# Altium schematic sheet Copy of LCM.SchDoc
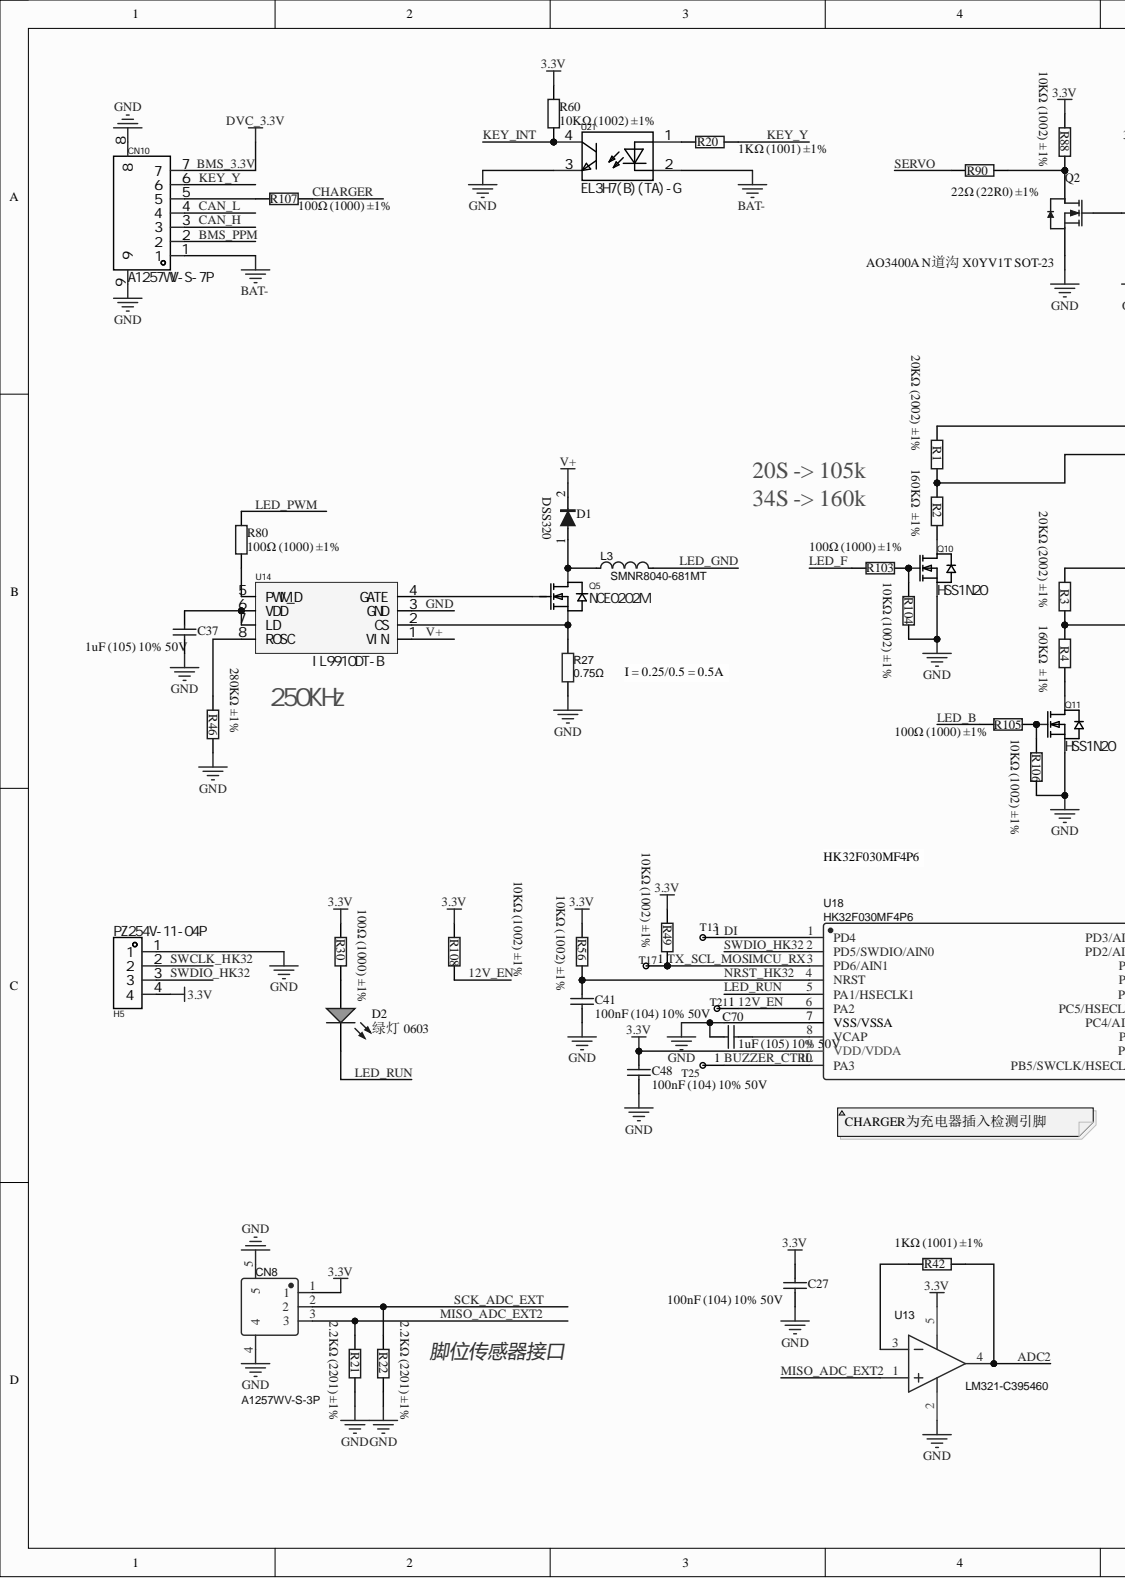
[diagram p0: Copy of LCM.SchDoc, left]
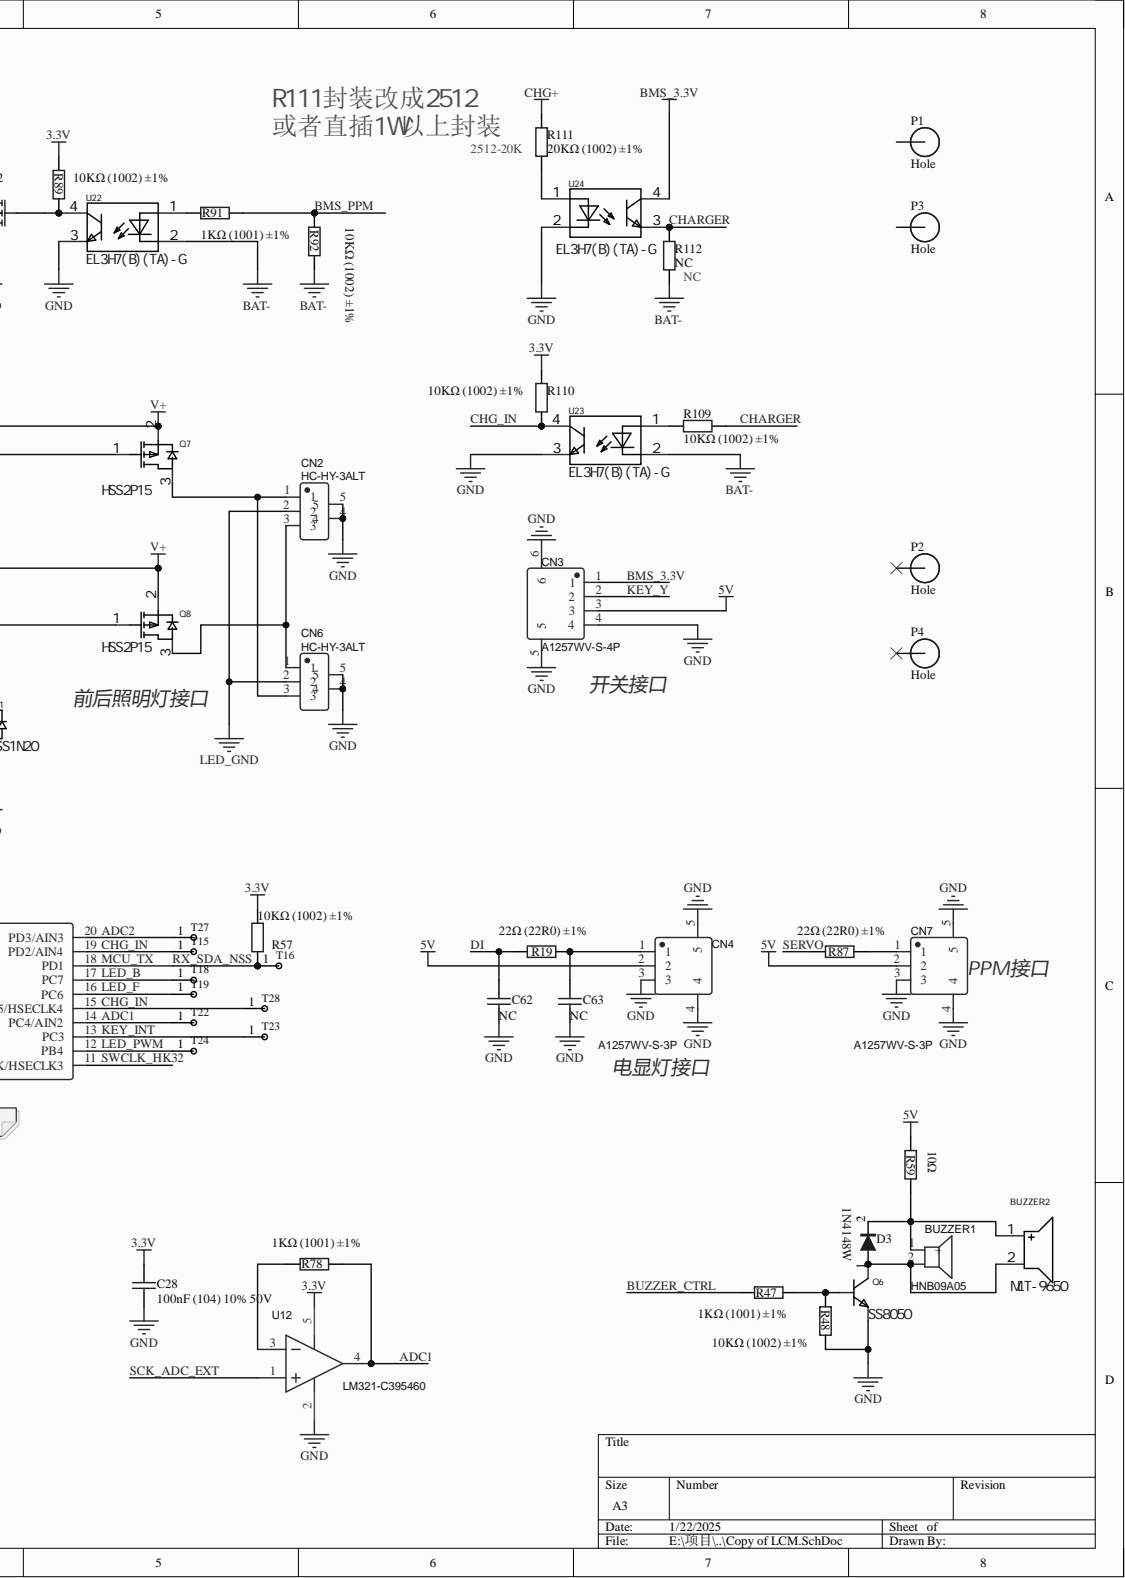
[diagram p1: Copy of LCM.SchDoc, right]

## Parts
BUZZER1  HNB09A05  [BUZ-TH_BD9.6-P5.00-D0.7-FD]
BUZZER2  MLT-9650  [BUZ-SMD_L9.6-W9.6-LS10.0-R]
C27  100nF (104) 10% 50V  [0603_C]
C28  100nF (104) 10% 50V  [0603_C]
C37  1uF (105) 10% 50V  [0603_C]
C41  100nF (104) 10% 50V  [0603_C]
C48  100nF (104) 10% 50V  [0603_C]
C62  NC  [0603_C]
C63  NC  [0603_C]
C70  1uF (105) 10% 50V  [0603_C]
CN2  HC-HY-3ALT  [CONN-SMD_HC-HY-3ALT]
CN3  A1257WV-S-4P  [CONN-SMD_A1257WV-S-4P]
CN4  A1257WV-S-3P  [CONN-SMD_A1257WV-S-3P]
CN6  HC-HY-3ALT  [CONN-SMD_HC-HY-3ALT]
CN7  A1257WV-S-3P  [CONN-SMD_A1257WV-S-3P]
CN8  A1257WV-S-3P  [CONN-SMD_A1257WV-S-3P]
CN10  A1257WV-S-7P  [CONN-SMD_A1257WV-S-7P]
D1  DSS320  [SOD-123]
D2  绿灯 0603  Package: 0603  [0603_LED_S1]
D3  1N4148W  [SOD-123]
H5  PZ254V-11-04P  [HDR-TH_4P-P2.54-V-M]
L3  SMNR8040-681MT  [IND-SMD_L8.0-W8.0]
P1  Hole  [Power Pad - Hole]
P2  Hole  [Power Pad - Hole]
P3  Hole  [Power Pad - Hole]
P4  Hole  [Power Pad - Hole]
Q2  AO3400A N道沟 X0YV1T SOT-23  Package: SOT-23  [SOT-23-3L]
Q5  NCE0202M  [SOT-89-3_L4.5-W2.5-P1.50-LS4.2-BR]
Q6  SS8050  [SOT-23-3_L2.9-W1.3-P1.90-LS2.4-BR]
Q7  HSS2P15  [SOT-23-3_L2.9-W1.6-P1.90-LS2.8-BR]
Q8  HSS2P15  [SOT-23-3_L2.9-W1.6-P1.90-LS2.8-BR]
Q10  HSS1N20  [SOT-23-3_L2.9-W1.6-P1.90-LS2.8-BR]
Q11  HSS1N20  [SOT-23-3_L2.9-W1.6-P1.90-LS2.8-BR]
R1  20KΩ (2002) ±1%  [0603_R]
R2  160KΩ  ±1%  [0603_R]
R3  20KΩ (2002) ±1%  [0603_R]
R4  160KΩ  ±1%  [0603_R]
R19  22Ω (22R0) ±1%  [0603_R]
R20  1KΩ (1001) ±1%  [0603_R]
R21  2.2KΩ (2201) ±1%  [0603_R]
R22  2.2KΩ (2201) ±1%  [0603_R]
R27  0.75Ω  [1206_R]
R30  100Ω (1000) ±1%  [0603_R]
R42  1KΩ (1001) ±1%  [0603_R]
R46  280KΩ ±1%  [0603_R]
R47  1KΩ (1001) ±1%  [0603_R]
R48  10KΩ (1002) ±1%  [0603_R]
R49  10KΩ (1002) ±1%  [0603_R]
R56  10KΩ (1002) ±1%  [0603_R]
R57  10KΩ (1002) ±1%  [0603_R]
R59  10Ω  [0805_R]
R60  10KΩ (1002) ±1%  [0603_R]
R78  1KΩ (1001) ±1%  [0603_R]
R80  100Ω (1000) ±1%  [0603_R]
R87  22Ω (22R0) ±1%  [0603_R]
R88  10KΩ (1002) ±1%  [0603_R]
R89  10KΩ (1002) ±1%  [0603_R]
R90  22Ω (22R0) ±1%  [0603_R]
R91  1KΩ (1001) ±1%  [0603_R]
R92  10KΩ (1002) ±1%  [0603_R]
R103  100Ω (1000) ±1%  [0603_R]
R104  10KΩ (1002) ±1%  [0603_R]
R105  100Ω (1000) ±1%  [0603_R]
R106  10KΩ (1002) ±1%  [0603_R]
R107  100Ω (1000) ±1%  [0603_R]
R108  10KΩ (1002) ±1%  [0603_R]
R109  10KΩ (1002) ±1%  [0603_R]
R110  10KΩ (1002) ±1%  [0603_R]
R111  20KΩ (1002) ±1%  [2512_R]
R112  NC  [0603_R]
T13  Test Point  [TESTPOINT_1MM - small]  (value not drawn)
T15  Test Point  [TESTPOINT_1MM - small]  (value not drawn)
T16  Test Point  [TESTPOINT_1MM - small]  (value not drawn)
T17  Test Point  [TESTPOINT_1MM - small]  (value not drawn)
T18  Test Point  [TESTPOINT_1MM - small]  (value not drawn)
T19  Test Point  [TESTPOINT_1MM - small]  (value not drawn)
T21  Test Point  [TESTPOINT_1MM - small]  (value not drawn)
T22  Test Point  [TESTPOINT_1MM - small]  (value not drawn)
T23  Test Point  [TESTPOINT_1MM - small]  (value not drawn)
T24  Test Point  [TESTPOINT_1MM - small]  (value not drawn)
T25  Test Point  [TESTPOINT_1MM - small]  (value not drawn)
T27  Test Point  [TESTPOINT_1MM - small]  (value not drawn)
T28  Test Point  [TESTPOINT_1MM - small]  (value not drawn)
U12  LM321-C395460  [SOT-23-5_L3.0-W1.7-P0.95-LS2.8-BL]
U13  LM321-C395460  [SOT-23-5_L3.0-W1.7-P0.95-LS2.8-BL]
U14  IL9910DT-B  [SO-8_L4.9-W3.9-P1.27-LS5.9-BL]
U18  HK32F030MF4P6  [TSSOP-20_L6.5-W4.4-P0.65-LS6.4-BL]
U21  EL3H7(B)(TA)-G  [SOP-4_L4.4-W2.8-P1.27-LS7.0-TL]
U22  EL3H7(B)(TA)-G  [SOP-4_L4.4-W2.8-P1.27-LS7.0-TL]
U23  EL3H7(B)(TA)-G  [SOP-4_L4.4-W2.8-P1.27-LS7.0-TL]
U24  EL3H7(B)(TA)-G  [SOP-4_L4.4-W2.8-P1.27-LS7.0-TL]

## Nets
3.3V: C27.1 C28.1 C48.1 CN8.1 H5.4 R30.2 R49.1 R56.1 R57.1 R60.1 R88.1 R89.1 R108.1 R110.1 U12.5 U13.5 U18.9(VDD/VDDA)
5V: CN3.3 CN4.2 CN7.2 R59.2
BAT-: CN10.1 R92.2 R112.1 U21.2 U22.2 U23.2
CHG+: R111.2
12V_EN: R108.2 T21.1 U18.6(PA2)
ADC1: R78.1 T22.1 U12.4 U18.14(PC4/AIN2)
ADC2: R42.1 T27.1 U13.4 U18.20(PD3/AIN3)
BMS_3.3V: CN3.1 CN10.7 U24.4
BMS_PPM: CN10.2 R91.1 R92.1
BUZZER_CTRL: R47.2 T25.1 U18.10(PA3)
CAN_H: CN10.3
CAN_L: CN10.4
CHARGER: R107.1 R109.2 R112.2 U24.3
CHG_IN: R110.2 T15.1 T28.1 U18.15(PC5/HSECLK4) U18.19(PD2/AIN4) U23.4
DI: C62.1 R19.1 T13.1 U18.1(PD4)
GND: C27.2 C28.2 C37.1 C41.2 C48.2 C62.2 C63.2 C70.1 CN2.4 CN2.5 CN3.4 CN3.5 CN3.6 CN4.3 CN4.4 CN4.5 CN6.4 CN6.5 CN7.3 CN7.4 CN7.5 CN8.4 CN8.5 CN10.8 CN10.9 H5.1 Q2.2 Q6.2 Q10.2 Q11.2 R21.1 R22.1 R27.2 R46.1 R48.2 R104.2 R106.2 U12.2 U13.2 U14.3(GND) U18.7(VSS/VSSA) U21.3 U22.3 U23.3 U24.2
KEY_INT: R60.2 T23.1 U18.13(PC3) U21.4
KEY_Y: CN3.2 CN10.6 R20.1
LED_B: R105.2 T18.1 U18.17(PC7)
LED_F: R103.2 T19.1 U18.16(PC6)
LED_GND: CN2.2 CN6.2 L3.2
LED_PWM: R80.2 T24.1 U18.12(PB4)
LED_RUN: D2.K U18.5(PA1/HSECLK1)
MCU_RX: R49.2 T17.1 U18.3(PD6/AIN1)
MCU_TX: R57.2 T16.1 U18.18(PD1)
MISO_ADC_EXT2: CN8.3 R21.2 U13.1
NRST_HK32: C41.1 R56.2 U18.4(NRST)
SCK_ADC_EXT: CN8.2 R22.2 U12.1
SERVO: R87.1 R90.1
SWCLK_HK32: H5.2 U18.11(PB5/SWCLK/HSECLK3)
SWDIO_HK32: H5.3 U18.2(PD5/SWDIO/AIN0)
V+: D1.2 Q7.2 Q8.2 R1.1 R3.1 U14.1(VIN)
NetBUZZER1_1: BUZZER1.1 BUZZER2.1 D3.2 R59.1
NetBUZZER1_2: BUZZER1.2 BUZZER2.2 D3.1 Q6.3
NetC37_2: C37.2 U14.6(VDD) U14.7(LD)
NetC63_1: C63.1 CN4.1 R19.2
NetC70_2: C70.2 U18.8(VCAP)
NetCN2_1: CN2.1 CN6.3 Q7.3
NetCN2_3: CN2.3 CN6.1 Q8.3
NetCN7_1: CN7.1 R87.2
NetCN10_5: CN10.5 R107.2
NetD1_1: D1.1 L3.1 Q5.2
NetD2_A: D2.A R30.1
NetQ2_1: Q2.1 R89.2 U22.4
NetQ2_3: Q2.3 R88.2 R90.2
NetQ5_1: Q5.1 U14.4(GATE)
NetQ5_3: Q5.3 R27.1 U14.2(CS)
NetQ6_1: Q6.1 R47.1 R48.1
NetQ7_1: Q7.1 R1.2 R2.1
NetQ8_1: Q8.1 R3.2 R4.1
NetQ10_1: Q10.1 R103.1 R104.1
NetQ10_3: Q10.3 R2.2
NetQ11_1: Q11.1 R105.1 R106.1
NetQ11_3: Q11.3 R4.2
NetR20_2: R20.2 U21.1
NetR42_2: R42.2 U13.3
NetR46_2: R46.2 U14.8(ROSC)
NetR78_2: R78.2 U12.3
NetR80_1: R80.1 U14.5(PWM_D)
NetR91_2: R91.2 U22.1
NetR109_1: R109.1 U23.1
NetR111_1: R111.1 U24.1

Not connected: P1 1; P2 1; P3 1; P4 1

## Library data of the parts
BUZZER1 = HNB09A05: BOM_Manufacturer: HNDZ; BOM_Manufacturer Part: HNB09A05; BOM_Supplier: LCSC; BOM_Supplier Part: C96493; Component Kind: Standard; spicePre: B; spiceSymbolName: HNB09A05
BUZZER2 = MLT-9650: Manufacturer: 华能; Add into BOM: yes; Operating Voltage: 3V~7V; Oscillator Circuit: 有源(内含驱动线路); Sound Pressure Level (SPL): 85dB@5V,10cm; Symbol: HND-9650B; Technology Type: 电磁式; Value: 2.7kHz; Component Kind: Standard; Convert to PCB: yes; Datasheet: https://atta.szlcsc.com/upload/public/pdf/source/20170914/C96127_1505374148680969227.pdf; Device: MLT-9650; Height: 5.5mm; JLCPCB Part Class: Extended Part; LCSC Part Name: 耐环保MLT-9650; Length: 9.6mm; Manufacturer Part: MLT-9650; Supplier: LCSC; Supplier Footprint: -; Supplier Part: C96127; Width: 9.6mm
C27 C28 C41 C48 C62 C63 = 0603 100nF (104) 10% 50V: 贴片电容; Component Kind: Standard; ComponentLink1Description: 供应商链接; ComponentLink1URL: http://www.szlcsc.com/product/details_15331.html; Library Name: Cap.SchLib; Package: 0603; Supplier: LC; SuppliersPartNumber: C14663
C37 C70 = 0603 1uF (105) 10% 50V: 贴片电容; Component Kind: Standard; ComponentLink1Description: 供应商链接; ComponentLink1URL: http://www.szlcsc.com/product/details_16531.html; Package: 0603; Supplier: LC; SuppliersPartNumber: C15849
  C37: Library Name: Cap.SchLib
  C70: Library Name: Miscellaneous Devices LC.IntLib
CN2 CN6 = HC-HY-3ALT: BOM_Manufacturer: HCTL(华灿天禄); Contributor: LCSC; BOM_Manufacturer Part: HC-HY-3ALT; BOM_Supplier: LCSC; BOM_Supplier Part: C2845717; Component Kind: Standard; spicePre: C; spiceSymbolName: HC-HY-3ALT
CN3 = A1257WV-S-4P: BOM_Manufacturer: Changjiang Connectors; Contributor: LCSC; BOM_JLCPCB Part Class: Extended Part; BOM_Manufacturer Part: A1257WV-S-4P; BOM_Supplier: LCSC; BOM_Supplier Part: C225120; Component Kind: Standard; spicePre: C; spiceSymbolName: A1257WV-S-4P
CN4 CN7 CN8 = A1257WV-S-3P: BOM_Manufacturer: Changjiang Connectors; Contributor: LCSC; BOM_JLCPCB Part Class: Extended Part; BOM_Manufacturer Part: A1257WV-S-3P; BOM_Supplier: LCSC; BOM_Supplier Part: C225119; Component Kind: Standard; Library Name: SCH.SchLib; spicePre: C; spiceSymbolName: A1257WV-S-3P
CN10 = A1257WV-S-7P: Manufacturer: CJT(长江连接器); Add into BOM: yes; Number of PINs Per Row: 7; Pins Structure: 1x7P; Pitch: 1.25mm; Reference Series: GH; Component Kind: Standard; Contact Material: 黄铜; Convert to PCB: yes; Datasheet: https://atta.szlcsc.com/upload/public/pdf/source/20181008/C272994_C9E89FA8A36D0009CAD30AD8C59C2782.pdf; Device: A1257WV-S-7P; JLCPCB Part Class: Extended Part; LCSC Part Name: 系列:GH 间距:1.25mm 1x7P; Manufacturer Part: A1257WV-S-7P; Mounting Style: -; Number of Pins: 7P; Number of Rows: 1; Row Spacing: -; Supplier: LCSC; Supplier Footprint: SMD,P=1.25mm; Supplier Part: C225123; Symbol: A1257WV-S-7P
D1 D3 = SOD-123 1N4148W: 二极管; Component Kind: Standard; Library Name: Diode.SchLib
D2 = 0603 绿灯: 发光二极管; Component Kind: Standard; ComponentLink1Description: 供应商链接; ComponentLink1URL: http://www.szlcsc.com/product/details_73152.html; Library Name: LED.SchLib; Supplier: LC; SuppliersPartNumber: C72043
H5 = PZ254V-11-04P: Manufacturer: XFCN(兴飞); Add into BOM: yes; Circluar Pins/Square Pins: 方针; Current Rating (Max): 3A; Pin Structure: 1x4P; Pitch: 2.54mm; Symbol: PZ254V-11-04P_C2691448; Voltage Rating (Max): 250V; Color: 黑色; Component Kind: Standard; Contact Material: -; Convert to PCB: yes; Datasheet: https://atta.szlcsc.com/upload/public/pdf/source/20200309/C492403_F9F19131791D08044AD4F84F8E751A34.pdf; Device: PZ254V-11-04P; Insulation Height: 2.5mm; JLCPCB Part Class: Extended Part; LCSC Part Name: 间距:2.54mm 1x4P 直插 方针; Length of End Connection Pin: 3mm; Length of Mating Pin: 6mm; Manufacturer Part: PZ254V-11-04P; Mounting Type: 直插; Number of Pins: 4P; Number of Rows: 单排; Operating Temperature Range: -40℃~+105℃; Row Spacing: -; Supplier: LCSC; Supplier Footprint: 插件,P=2.54mm; Supplier Part: C2691448
L3 = 220uH: BOM_Manufacturer: Sunlord; BOM_Manufacturer Part: SWPA8040S221MT; nameAlias: Inductance; BOM_JLCPCB Part Class: Extended Part; BOM_Supplier: LCSC; BOM_Supplier Part: C86663; Component Kind: Standard; spicePre: L; spiceSymbolName: SWPA8040S221MT; Library Name: 灯控板.SchLib
P1-P4 = 贴片螺母: Component Kind: Standard; Library Name: SCH.SchLib
Q2 = AO3400A N道沟 X0YV1T SOT-23: MOS(场效应管); Component Kind: Standard; ComponentLink1Description: 供应商链接; ComponentLink1URL: http://www.szlcsc.com/product/details_21629.html; Library Name: MOS.SchLib; Supplier: LC; SuppliersPartNumber: C20917
Q5 = NCE0202M: Manufacturer: NCE(无锡新洁能); Add into BOM: yes; Continuous Drain Current (Id): 2A; Drain Source On Resistance (RDS(on)@Vgs,Id): 520mΩ@10V,2A; Drain Source Voltage (Vdss): 200V; Gate Threshold Voltage (Vgs(th)@Id): 1.8V@250uA; Input Capacitance (Ciss@Vds): 580pF@25V; Power Dissipation (Pd): 1.5W; Reverse Transfer Capacitance (Crss@Vds): 3pF@25V; Total Gate Charge (Qg@Vgs): 12nC@10V; Type: N沟道; Component Kind: Standard; Convert to PCB: yes; Datasheet: https://atta.szlcsc.com/upload/public/pdf/source/20210315/C2686539_296E07E2B517E9DEEBF2A06B2F3B3751.pdf; Device: NCE0202M; JLCPCB Part Class: Extended Part; LCSC Part Name: N沟道 耐压:200V 电流:2A; Manufacturer Part: NCE0202M; Operating Temperature: -55℃~+150℃@(Tj); Supplier: LCSC; Supplier Footprint: SOT-89-3; Supplier Part: C2686539; Symbol: NCE0202M
Q6 = SS8050_C2150: Manufacturer: CJ(江苏长电/长晶); Add into BOM: yes; Collector Current (Ic): 1.5A; Collector Cut-Off Current (Icbo): 100nA; Collector-Emitter Breakdown Voltage (Vceo): 25V; Collector-Emitter Saturation Voltage (VCE(sat)@Ic,Ib): 500mV@800mA,80mA; DC Current Gain (hFE@Ic,Vce): 200@100mA,1V; Power Dissipation (Pd): 300mW; Transistor Type: NPN; Transition Frequency (fT): 100MHz; Component Kind: Standard; Convert to PCB: yes; Datasheet: https://atta.szlcsc.com/upload/public/pdf/source/20230322/AEE86F4B043774EFF80CAEA14A37D9E9.pdf; Device: SS8050_C2150; JLCPCB Part Class: Basic Part; LCSC Part Name: NPN 电流:1.5A 耐压:25V hfe=200~350; Manufacturer Part: SS8050; Operating Temperature: -; Supplier: LCSC; Supplier Footprint: SOT-23; Supplier Part: C2150; Symbol: SS8050_C2150
Q7 Q8 = HSS2P15: Manufacturer: HUASHUO(华朔); Add into BOM: yes; Continuous Drain Current (Id): 2A; Drain Source On Resistance (RDS(on)@Vgs,Id): 650mΩ@10V,1A; Drain Source Voltage (Vdss): 150V; Gate Threshold Voltage (Vgs(th)@Id): 3V@250uA; Input Capacitance (Ciss@Vds): 715pF@75V; Power Dissipation (Pd): 2W; Reverse Transfer Capacitance (Crss@Vds): 14pF@75V; Total Gate Charge (Qg@Vgs): 10.5nC@10V; Type: P沟道; Component Kind: Standard; Convert to PCB: yes; Datasheet: https://atta.szlcsc.com/upload/public/pdf/source/20220331/0B6DE8A7264D90330CD6AE7CB9DAE8E7.pdf; Device: HSS2P15; JLCPCB Part Class: Extended Part; LCSC Part Name: P沟道 耐压:150V 电流:2A; Manufacturer Part: HSS2P15; Operating Temperature: -55℃~+150℃@(Tj); Supplier: LCSC; Supplier Footprint: SOT-23L; Supplier Part: C2987710; Symbol: HSS2P15
Q10 Q11 = HSS1N20: Manufacturer: HUASHUO(华朔); Add into BOM: yes; Continuous Drain Current (Id): 1A; Drain Source On Resistance (RDS(on)@Vgs,Id): 1.9Ω@10V,1A; Drain Source Voltage (Vdss): 200V; Gate Threshold Voltage (Vgs(th)@Id): 2.5V@250uA; Power Dissipation (Pd): 710mW; Type: N沟道; Component Kind: Standard; Convert to PCB: yes; Datasheet: https://atta.szlcsc.com/upload/public/pdf/source/20201013/C845589_D2D04504AFB971EFF5652784C1E024CF.pdf; Device: HSS1N20; JLCPCB Part Class: Extended Part; LCSC Part Name: N沟道 耐压:200V 电流:1A; Manufacturer Part: HSS1N20; Supplier: LCSC; Supplier Footprint: SOT-23L; Supplier Part: C845589; Symbol: HSS1N20
R1 R3 = 0402 20KΩ (2002) 1%: 贴片电阻; Component Kind: Standard; ComponentLink1Description: 供应商链接; ComponentLink1URL: http://www.szlcsc.com/product/details_26508.html; Library Name: RES.SchLib; Package: 0402; Supplier: LC; SuppliersPartNumber: C25765
R2 R4 R46 = 0402 100KΩ (1003) 1%: 贴片电阻; Component Kind: Standard; ComponentLink1Description: 供应商链接; ComponentLink1URL: http://www.szlcsc.com/product/details_26484.html; Package: 0402; Supplier: LC; SuppliersPartNumber: C25741
  R2 R4: Library Name: RES.SchLib
  R46: Library Name: Miscellaneous Devices LC.IntLib
R19 R87 R90 = 0603 22Ω (22R0) 1%: 贴片电阻; Component Kind: Standard; ComponentLink1Description: 供应商链接; ComponentLink1URL: http://www.szlcsc.com/product/details_24078.html; Library Name: RES.SchLib; Package: 0603; Supplier: LC; SuppliersPartNumber: C23345
R20 R42 R47 R78 R91 = 0402 1KΩ (1001) 1%: 贴片电阻; Component Kind: Standard; ComponentLink1Description: 供应商链接; ComponentLink1URL: http://www.szlcsc.com/product/details_12256.html; Library Name: RES.SchLib; Package: 0402; Supplier: LC; SuppliersPartNumber: C11702
R21 R22 = 0603 2.2KΩ (2201) 1%: 贴片电阻; Component Kind: Standard; ComponentLink1Description: 供应商链接; ComponentLink1URL: http://www.szlcsc.com/product/details_4597.html; Library Name: RES.SchLib; Package: 0603; Supplier: LC; SuppliersPartNumber: C4190
R27 = 0.5Ω: BOM_Manufacturer: RALEC; BOM_Manufacturer Part: RTT06R500FTP; nameAlias: Resistance; Resistance (Ohms): 0.5; BOM_JLCPCB Part Class: Extended Part; BOM_Supplier: LCSC; BOM_Supplier Part: C104947; Component Kind: Standard; spicePre: R; spiceSymbolName: RTT06R500FTP
R30 R80 R103 R105 R107 = 0603 100Ω (1000) 1%: 贴片电阻; Component Kind: Standard; ComponentLink1Description: 供应商链接; ComponentLink1URL: http://www.szlcsc.com/product/details_23502.html; Library Name: RES.SchLib; Package: 0603; Supplier: LC; SuppliersPartNumber: C22775
R48 R49 R56 R57 R60 R88 R89 R92 R104 R106 R108-R112 = 0603 10KΩ (1002) 1%: 贴片电阻; Component Kind: Standard; ComponentLink1Description: 供应商链接; ComponentLink1URL: http://www.szlcsc.com/product/details_26547.html; Library Name: Miscellaneous Devices LC.IntLib; Package: 0603; Supplier: LC; SuppliersPartNumber: C25804
R59 = 0805 0Ω (0R0) 1%: 贴片电阻; Component Kind: Standard; ComponentLink1Description: 供应商链接; ComponentLink1URL: http://www.szlcsc.com/product/details_18165.html; Library Name: RES.SchLib; Package: 0805; Supplier: LC; SuppliersPartNumber: C17477
T13 T15-T19 T21-T25 T27 T28 = U: Component Kind: Standard
U12 U13 = LM321-C395460: BOM_Manufacturer: IDCHIP; BOM_Manufacturer Part: LM321; Contributor: LCSC; BOM_JLCPCB Part Class: Extended Part; BOM_Supplier: LCSC; BOM_Supplier Part: C395460; Component Kind: Standard; spicePre: U; spiceSymbolName: LM321-C395460
U14 = AL9910-5S-13: Manufacturer: DIODES(美台); Add into BOM: yes; Channels: 1; Input Voltage: 15V~500V; Topology: 降压；升压; Component Kind: Standard; Convert to PCB: yes; Datasheet: https://atta.szlcsc.com/upload/public/pdf/source/20180814/C264082_8D0A5C9DFA7132160ED189DD8F4B121C.pdf; Device: AL9910-5S-13; Dimming: -; Features: -; JLCPCB Part Class: Extended Part; LCSC Part Name: AL9910-5S-13; Manufacturer Part: AL9910-5S-13; Name: AL9910-5S-13; Operating temperature: -40℃~+85℃; Output Voltage: -; Supplier: LCSC; Supplier Footprint: SO-8; Supplier Part: C264082; Switch Frequency: -; Symbol: AL9910-5S-13; Type: -; Library Name: 灯控板.SchLib
U18 = HK32F030MF4P6: BOM_Manufacturer: HK; BOM_Paste Type: expand; Contributor: LCSC; BOM_Manufacturer Part: HK32F030MF4P6; BOM_Supplier: LCSC; BOM_Supplier Part: C707444; Component Kind: Standard; spicePre: U; spiceSymbolName: HK32F030MF4P6
U21-U24 = EL3H7(B)(TA)-G: Manufacturer: EVERLIGHT(亿光); Add into BOM: yes; Collector-Emitter Saturation Voltage (Vce(sat)@Ic,IF): 100mV@1mA,10mA; Fall time: 3us@2mA,100Ω; Forward Voltage: 1.2V; Input voltage type: DC; Isolation Voltage(rms): 3.75kV; Number of Outputs: 1; Output Current: 50mA; Output Type: 光电三极管; Receiving End Voltage: 80V; Reverse Voltage: 6V; Rise time: 5us@2mA,100Ω; Component Kind: Standard; Convert to PCB: yes; Datasheet: https://atta.szlcsc.com/upload/public/pdf/source/20140621/1457707095564.pdf; Device: EL3H7(B)(TA)-G; JLCPCB Part Class: Basic Part; LCSC Part Name: DC输入 隔离电压(rms):3750V; Manufacturer Part: EL3H7(B)(TA)-G; Operating Temperature: -55℃~+110℃; Supplier: LCSC; Supplier Footprint: SOP-4-1.27mm; Supplier Part: C32565; Symbol: EL3H7(B)(TA)-G
